# Altium schematic sheet 02_MCU_Interface.SchDoc
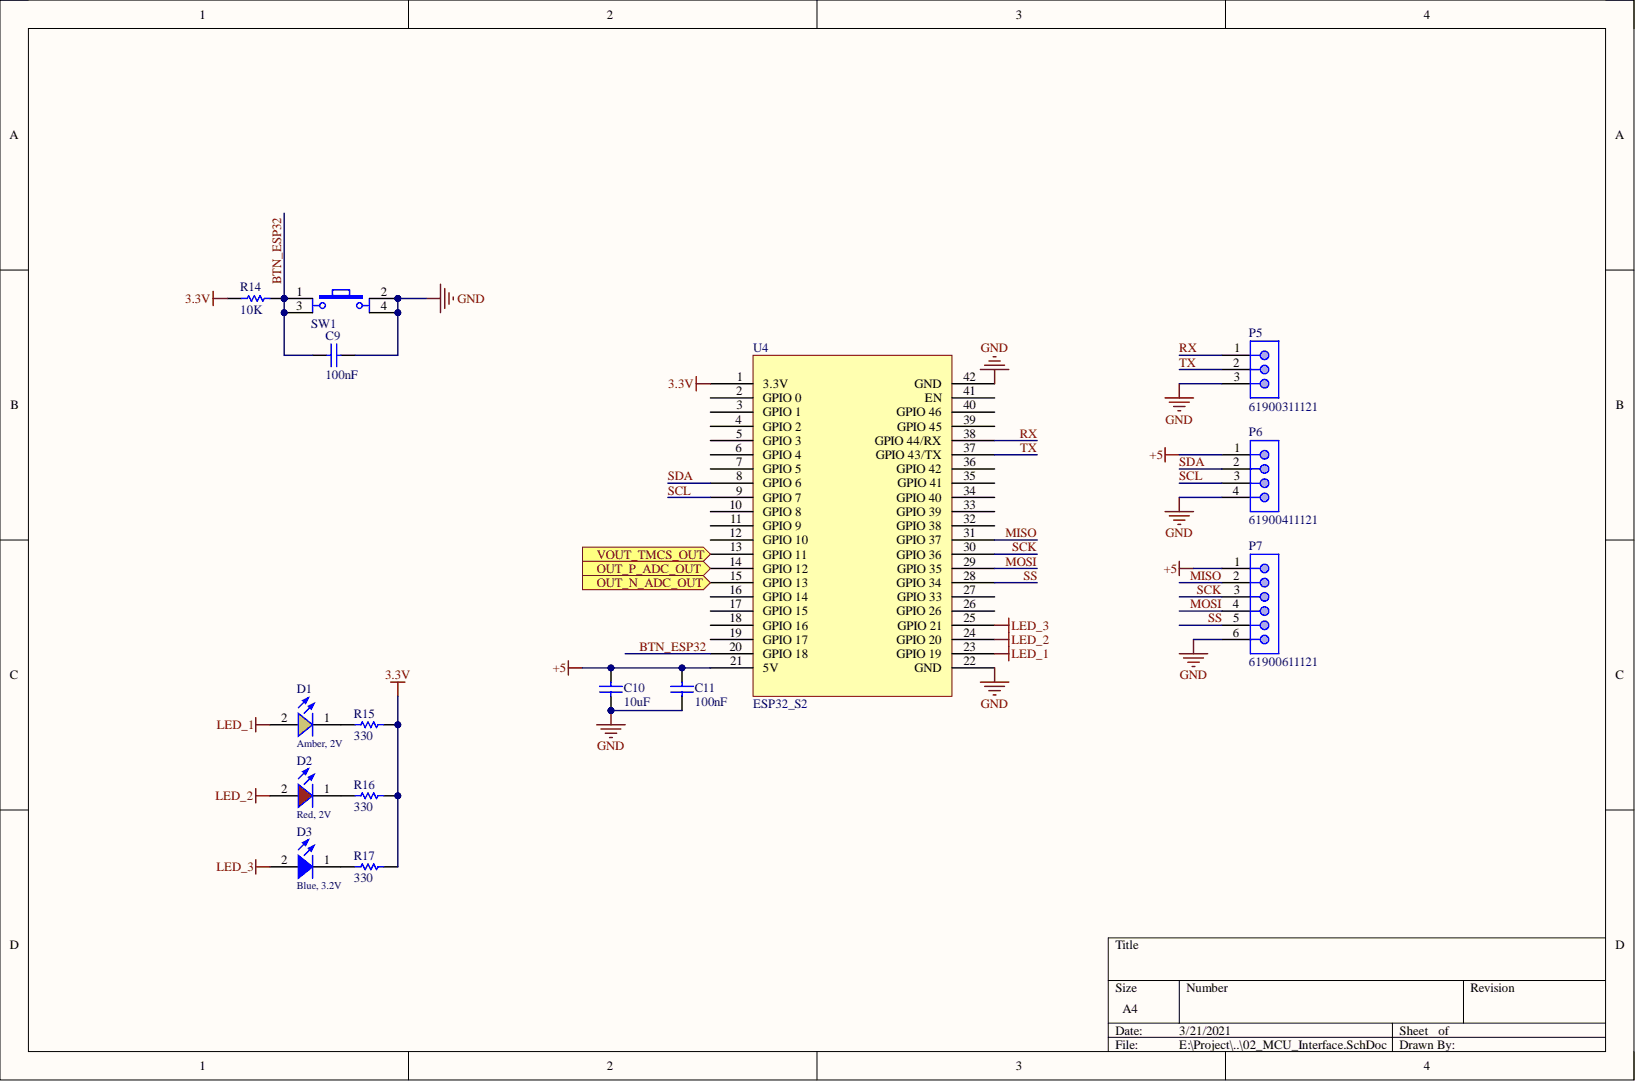
[diagram p0: 02_MCU_Interface.SchDoc]

## Parts
C9  value 100nF  [CAP-SM-0805]  (hidden comment: Cap Non-Pol SMD)
C10  value 10uF  [CAP-SM-0805]  (hidden comment: Cap Non-Pol SMD)
C11  value 100nF  [CAP-SM-0805]  (hidden comment: Cap Non-Pol SMD)
D1  Amber, 2V  Forward Voltage typ.: 2V  [WL-SMCW_0603_H=0.7mm]
D2  Red, 2V  Forward Voltage typ.: 2V  [WL-SMCW_0603_H=0.7mm]
D3  Blue, 3.2V  Forward Voltage typ.: 3.2V  [WL-SMCW_0603_H=0.7mm]
P5  61900311121  [61900311121]
P6  61900411121  [61900411121]
P7  61900611121  [61900611121]
R14  value 10K  [RES 1/2W]  (hidden comment: RESISTOR)
R15  value 330  [RES-SM-0603]  (hidden comment: RESISTOR SMD)
R16  value 330  [RES-SM-0603]  (hidden comment: RESISTOR SMD)
R17  value 330  [RES-SM-0603]  (hidden comment: RESISTOR SMD)
SW1  SW  [Tact SW 4 pin]
U4  ESP32_S2  [ESP32_S2]

## Ports
OUT_N_ADC_OUT, OUT_P_ADC_OUT, VOUT_TMCS_OUT

## Nets
3.3V: R14.1 R15.2 R16.2 R17.2 U4.1(3.3V)
+5: C10.1 C11.1 P6.1 P7.1 U4.21(5V)
GND: C9.2 C10.2 C11.2 P5.3 P6.4 P7.6 SW1.2 SW1.4 U4.22(GND) U4.42(GND)
LED_1: D1.2 U4.23(GPIO_19)
LED_2: D2.2 U4.24(GPIO_20)
LED_3: D3.2 U4.25(GPIO_21)
BTN_ESP32: C9.1 R14.2 SW1.1 SW1.3 U4.20(GPIO_18)
MISO: P7.2 U4.31(GPIO_37)
MOSI: P7.4 U4.29(GPIO_35)
OUT_N_ADC_OUT: U4.15(GPIO_13) ; port OUT_N_ADC_OUT
OUT_P_ADC_OUT: U4.14(GPIO_12) ; port OUT_P_ADC_OUT
RX: P5.1 U4.38(GPIO_44/RX)
SCK: P7.3 U4.30(GPIO_36)
SCL: P6.3 U4.9(GPIO_7)
SDA: P6.2 U4.8(GPIO_6)
SS: P7.5 U4.28(GPIO_34)
TX: P5.2 U4.37(GPIO_43/TX)
VOUT_TMCS_OUT: U4.13(GPIO_11) ; port VOUT_TMCS_OUT
NetD1_1: D1.1 R15.1
NetD2_1: D2.1 R16.1
NetD3_1: D3.1 R17.1

Not connected: U4 2(GPIO_0) 3(GPIO_1) 4(GPIO_2) 5(GPIO_3) 6(GPIO_4) 7(GPIO_5) 10(GPIO_8) 11(GPIO_9) 12(GPIO_10) 16(GPIO_14) 17(GPIO_15) 18(GPIO_16) 19(GPIO_17) 26(GPIO_26) 27(GPIO_33) 32(GPIO_38) 33(GPIO_39) 34(GPIO_40) 35(GPIO_41) 36(GPIO_42) 39(GPIO_45) 40(GPIO_46) 41(EN)

## Library data of the parts
C9-C11 = Cap Non-Pol SMD: Capacitor; Component Kind: Standard; Library Name: PIF_Library.IntLib
D1 = 150060AS75000: WL-SMCW SMT Mono-color Chip LED Waterclear, size 0603, Amber, 2V, 140deg; Chip Technology: AlInGaP; Dominant Wavelength typ.: 605nm; Luminous Intensity typ.: 130mcd; Peak Wavelength typ.: 610nm; Component Kind: Standard; ComponentLink1Description: Manufacturer; ComponentLink1URL: http://www.we-online.de; ComponentLink2Description: Datasheet; ComponentLink2URL: https://www.we-online.com/catalog/datasheet/150060AS75000.pdf; Emitting Color: Amber; Library Name: Altium_WL-SMCW (rev20a).IntLib; Mounting Technology: SMT; Operating Temperature: -40 to +85degC; Packaging: Tape and Reel; Part Number: 150060AS75000; Published Date: December 2019; Size: 0603; Viewing Angle: 140deg; Publisher: Wurth Elektronik
D2 = 150060RS75000: WL-SMCW SMT Mono-color Chip LED Waterclear, size 0603, Red, 2V, 140deg; Chip Technology: AlInGaP; Dominant Wavelength typ.: 625nm; Luminous Intensity typ.: 250mcd; Peak Wavelength typ.: 630nm; Component Kind: Standard; ComponentLink1Description: Manufacturer; ComponentLink1URL: http://www.we-online.de; ComponentLink2Description: Datasheet; ComponentLink2URL: https://www.we-online.com/catalog/datasheet/150060RS75000.pdf; Emitting Color: Red; Library Name: Altium_WL-SMCW (rev20a).IntLib; Mounting Technology: SMT; Operating Temperature: -40 to +85degC; Packaging: Tape and Reel; Part Number: 150060RS75000; Published Date: December 2019; Size: 0603; Viewing Angle: 140deg; Publisher: Wurth Elektronik
D3 = 150060BS75000: WL-SMCW SMT Mono-color Chip LED Waterclear, size 0603, Blue, 3.2V, 140deg; Chip Technology: InGaN; Dominant Wavelength typ.: 470nm; Luminous Intensity typ.: 145mcd; Peak Wavelength typ.: 465nm; Component Kind: Standard; ComponentLink1Description: Manufacturer; ComponentLink1URL: http://www.we-online.de; ComponentLink2Description: Datasheet; ComponentLink2URL: https://www.we-online.com/catalog/datasheet/150060BS75000.pdf; Emitting Color: Blue; Library Name: Altium_WL-SMCW (rev20a).IntLib; Mounting Technology: SMT; Operating Temperature: -40 to +85degC; Packaging: Tape and Reel; Part Number: 150060BS75000; Published Date: December 2019; Size: 0603; Viewing Angle: 140deg; Publisher: Wurth Elektronik
P5 = 61900311121: WR-WTB THT Male Vertical Locking Header, pitch 2.54mm, 3p; Contact Resistance: 20mOhm; Pin Number: 3; Rated Current: 3A; Withstanding Voltage: 1000VAC; Working Voltage: 250VAC; Component Kind: Standard; ComponentLink1Description: Manufacturer; ComponentLink1URL: http://www.we-online.de; ComponentLink2Description: Datasheet; ComponentLink2URL: https://www.we-online.com/catalog/datasheet/61900311121.pdf; Gender: Male; Library Name: 653008114822_Altium_WR-WTB_rev20a.IntLib; Mounting Technology: THT; Operating Temperature: -40 to +105degC; Packaging: Bag; Part Number: 61900311121; Pitch: 2.54mm; Published Date: January 2020; Type: Vertical; Publisher: Wurth Elektronik
P6 = 61900411121: WR-WTB THT Male Vertical Locking Header, pitch 2.54mm, 4p; Contact Resistance: 20mOhm; Pin Number: 4; Rated Current: 3A; Withstanding Voltage: 1000VAC; Working Voltage: 250VAC; Component Kind: Standard; ComponentLink1Description: Manufacturer; ComponentLink1URL: http://www.we-online.de; ComponentLink2Description: Datasheet; ComponentLink2URL: https://www.we-online.com/catalog/datasheet/61900411121.pdf; Gender: Male; Library Name: 653008114822_Altium_WR-WTB_rev20a.IntLib; Mounting Technology: THT; Operating Temperature: -40 to +105degC; Packaging: Bag; Part Number: 61900411121; Pitch: 2.54mm; Published Date: January 2020; Type: Vertical; Publisher: Wurth Elektronik
P7 = 61900611121: WR-WTB THT Male Vertical Locking Header, pitch 2.54mm, 6p; Contact Resistance: 20mOhm; Pin Number: 6; Rated Current: 3A; Withstanding Voltage: 1000VAC; Working Voltage: 250VAC; Component Kind: Standard; ComponentLink1Description: Manufacturer; ComponentLink1URL: http://www.we-online.de; ComponentLink2Description: Datasheet; ComponentLink2URL: https://www.we-online.com/catalog/datasheet/61900611121.pdf; Gender: Male; Library Name: 653008114822_Altium_WR-WTB_rev20a.IntLib; Mounting Technology: THT; Operating Temperature: -40 to +105degC; Packaging: Bag; Part Number: 61900611121; Pitch: 2.54mm; Published Date: January 2020; Type: Vertical; Publisher: Wurth Elektronik
R15-R17 = RESISTOR SMD: Resistor; Component Kind: Standard; Library Name: PIF_Library.IntLib
SW1 = Tact SW - 4Pin: Switch button 4 pin; Value: Button chip 4 pin; Component Kind: Standard; Library Name: PIF_Library.IntLib
R14 = RESISTOR: Component Kind: Standard; Description: Resistor; Library Name: PIF_Library.IntLib
U4 = ESP32_S2: Component Kind: Standard; Library Name: MiclsLib.IntLib
